# Altium schematic sheet Interface.SchDoc(Interface)
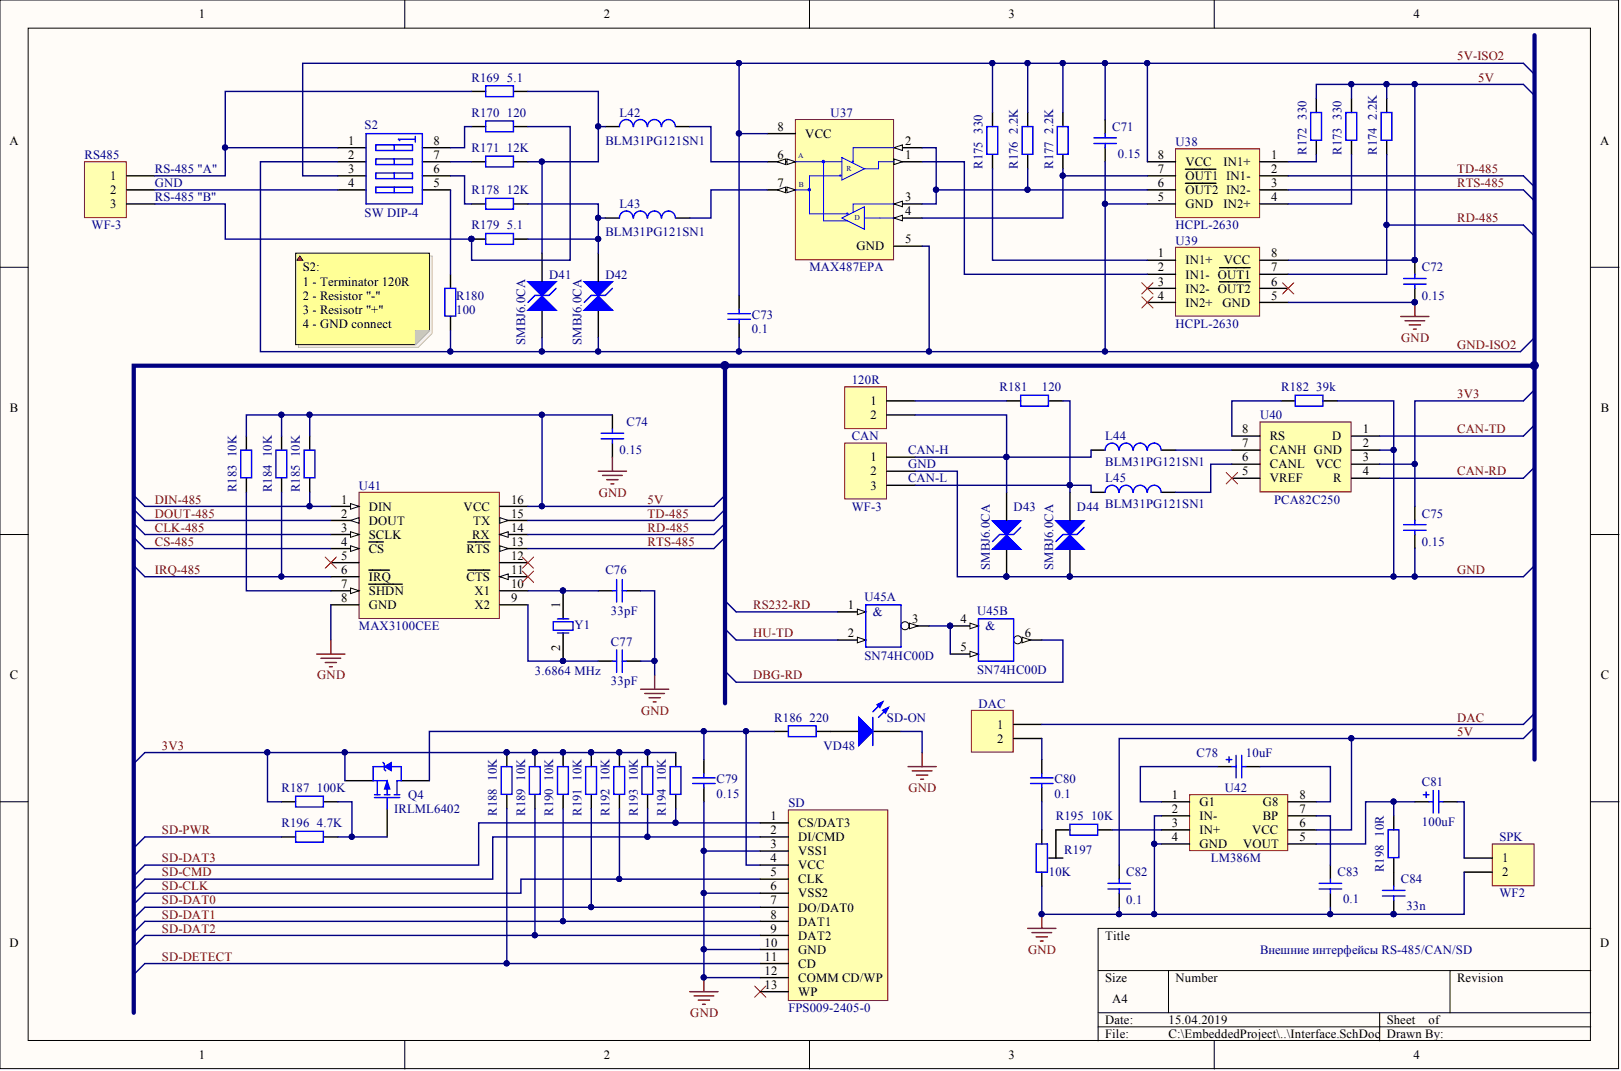
[diagram p0: Interface.SchDoc]

## Parts
120R  JP2  [HDR1X2]  (value not drawn)
C71  value 0.15  [C1206]  (hidden comment: Cap)
C72  value 0.15  [C1206]  (hidden comment: Cap)
C73  value 0.1  [C1206]  (hidden comment: Cap)
C74  value 0.15  [C1206]  (hidden comment: Cap)
C75  value 0.15  [C1206]  (hidden comment: Cap)
C76  value 33pF  [C1206]  (hidden comment: Cap)
C77  value 33pF  [C1206]  (hidden comment: Cap)
C78  value 10uF  [D]  (hidden comment: Polarized Capacitor)
C79  value 0.15  [C1206]  (hidden comment: Cap)
C80  value 0.1  [C1206]  (hidden comment: Cap)
C81  value 100uF  [D]  (hidden comment: Polarized Capacitor)
C82  value 0.1  [C1206]  (hidden comment: Cap)
C83  value 0.1  [C1206]  (hidden comment: Cap)
C84  value 33n  [C1206]  (hidden comment: Cap)
CAN  value WF-3  [WF-3]  (hidden comment: Header, 3-Pin)
D41  SMBJ6.0CA  [DO-214aa]
D42  SMBJ6.0CA  [DO-214aa]
D43  SMBJ6.0CA  [DO-214aa]
D44  SMBJ6.0CA  [DO-214aa]
L42  value BLM31PG121SN1  [L1206]  (hidden comment: Ferrite Chip Beads)
L43  value BLM31PG121SN1  [L1206]  (hidden comment: Ferrite Chip Beads)
L44  value BLM31PG121SN1  [L1206]  (hidden comment: Ferrite Chip Beads)
L45  value BLM31PG121SN1  [L1206]  (hidden comment: Ferrite Chip Beads)
Q4  IRLML6402  [SOT-23A_N]
R169  value 5.1  [1206]  (hidden comment: Res2)
R170  value 120  [1206]  (hidden comment: Res2)
R171  value 12K  [1206]  (hidden comment: Res2)
R172  value 330  [1206]  (hidden comment: Res2)
R173  value 330  [1206]  (hidden comment: Res2)
R174  value 2.2K  [1206]  (hidden comment: Res2)
R175  value 330  [1206]  (hidden comment: Res2)
R176  value 2.2K  [1206]  (hidden comment: Res2)
R177  value 2.2K  [1206]  (hidden comment: Res2)
R178  value 12K  [1206]  (hidden comment: Res2)
R179  value 5.1  [1206]  (hidden comment: Res2)
R180  value 100  [1206]  (hidden comment: Res2)
R181  value 120  [1206]  (hidden comment: Res2)
R182  value 39k  [1206]  (hidden comment: Res2)
R183  value 10K  [1206]  (hidden comment: Res2)
R184  value 10K  [1206]  (hidden comment: Res2)
R185  value 10K  [1206]  (hidden comment: Res2)
R186  value 220  [1206]  (hidden comment: Res2)
R187  value 100K  [1206]  (hidden comment: Res2)
R188  value 10K  [1206]  (hidden comment: Res2)
R189  value 10K  [1206]  (hidden comment: Res2)
R190  value 10K  [1206]  (hidden comment: Res2)
R191  value 10K  [1206]  (hidden comment: Res2)
R192  value 10K  [1206]  (hidden comment: Res2)
R193  value 10K  [1206]  (hidden comment: Res2)
R194  value 10K  [1206]  (hidden comment: Res2)
R195  value 10K  [1206]  (hidden comment: Res2)
R196  value 4.7K  [1206]  (hidden comment: Res2)
R197  value 10K  [R-Tr 3362P]  (hidden comment: Resistor TAP)
R198  value 10R  [1206]  (hidden comment: Res2)
RS485  value WF-3  [WF-3]  (hidden comment: Header 3)
S2  SW DIP-4  [SW DIP-8]
SD  FPS009-2405-0  [FPS009-2405-0]
SND  DAC  [HDR1X2]
SPK  WF2  [WF-2]
U37  value MAX487EPA  [DIP-8]  (hidden comment: Low-Power, Slew-Rate-Limited RS-485/RS-422 Transceiver)
U38  HCPL-2630  [DIP-8]
U39  HCPL-2630  [DIP-8]
U40  value PCA82C250  [8 pin SOIC_L]  (hidden comment: CAN transiver)
U41  MAX3100CEE  [QSOP-QR16_L]
U42  value LM386M  [8 pin SOIC_L]  (hidden comment: Audio Power Amplifier)
U45  SN74HC00D  [SOIC14_L]  (parts A,B)
VD48  SD-ON  [LED1206 - WHITE]
Y1  value 3.6864 MHz  [HC49S]  (hidden comment: Crystal Oscillator)

## Nets
VDD: U45.14
3V3: C75.1 Q4.2 R187.1 R188.2 R189.2 R190.2 R191.2 R192.2 R193.2 R194.2 U40.3(VCC)
5V: C72.1 C74.1 C82.2 R172.1 R173.1 R174.1 R183.1 R184.1 R185.1 U39.8(VCC) U41.16(VCC) U42.6(VCC)
5V-ISO2: C71.1 C73.1 R175.1 R176.1 R177.1 S2.3 U37.8(VCC) U38.8(VCC)
CAN-RD: U40.4(R)
CAN-TD: U40.1(D)
CLK-485: U41.3(SCLK)
CS-485: U41.4(CS)
DAC: SND.1
DBG-RD: U45.6
DIN-485: R185.2 U41.1(DIN)
DOUT-485: U41.2(DOUT)
GND: C72.2 C74.2 C75.2 C76.1 C77.1 C79.2 C82.1 C83.1 C84.1 CAN.2 D43.1 D44.1 R182.1 R197.1 SD.3(VSS1) SD.6(VSS2) SD.10(GND) SD.12(COMM_CD/WP) SPK.2 U39.5(GND) U40.2(GND) U41.8(GND) U42.2(IN-) U42.4(GND) U45.7 VD48.2
GND-ISO2: C71.2 C73.2 D41.1 D42.1 R180.1 S2.2 U37.5(GND) U38.5(GND)
HU-TD: U45.2
IRQ-485: R184.2 U41.6(IRQ)
RD-485: R174.2 U39.7(OUT1) U41.14(RX)
RS232-RD: U45.1(&)
RTS-485: U38.3(IN2-) U41.13(RTS)
SD-CLK: R192.1 SD.5(CLK)
SD-CMD: R193.1 SD.2(DI/CMD)
SD-DAT0: R191.1 SD.7(DO/DAT0)
SD-DAT1: R190.1 SD.8(DAT1)
SD-DAT2: R189.1 SD.9(DAT2)
SD-DAT3: R194.1 SD.1(CS/DAT3)
SD-DETECT: R188.1 SD.11(CD)
SD-PWR: R196.2
TD-485: U38.2(IN1-) U41.15(TX)
Net120R_1: 120R.1 R181.1
Net120R_2: 120R.2 CAN.1 D43.2 L44.1
NetC76_2: C76.2 U41.10(X1) Y1.1
NetC77_2: C77.2 U41.9(X2) Y1.2
NetC78_1: C78.1 U42.1(G1)
NetC78_2: C78.2 U42.8(G8)
NetC79_1: C79.1 Q4.3 R186.2 SD.4(VCC)
NetC80_1: C80.1 SND.2
NetC80_2: C80.2 R197.3
NetC81_1: C81.1 R198.2 U42.5(VOUT)
NetC81_2: C81.2 SPK.1
NetC83_2: C83.2 U42.7(BP)
NetC84_2: C84.2 R198.1
NetCAN_3: CAN.3 D44.2 L45.1 R181.2
NetD41_2: D41.2 L42.1 R169.1 R171.1
NetD42_2: D42.2 L43.1 R178.1 R179.1
NetL42_2: L42.2 U37.6
NetL43_2: L43.2 U37.7
NetL44_2: L44.2 U40.7(CANH)
NetL45_2: L45.2 U40.6(CANL)
NetQ4_1: Q4.1 R187.2 R196.1
NetR169_2: R169.2 RS485.1 S2.1
NetR170_1: R170.1 S2.8
NetR170_2: R170.2 R179.2 RS485.3
NetR171_2: R171.2 S2.7
NetR172_2: R172.2 U38.1(IN1+)
NetR173_2: R173.2 U38.4(IN2+)
NetR175_2: R175.2 U39.1(IN1+)
NetR176_2: R176.2 U37.2 U37.3 U38.6(OUT2)
NetR177_2: R177.2 U37.4 U38.7(OUT1)
NetR178_2: R178.2 S2.6
NetR180_2: R180.2 S2.5
NetR182_2: R182.2 U40.8(RS)
NetR183_2: R183.2 U41.7(SHDN)
NetR186_1: R186.1 VD48.1
NetR195_1: R195.1 R197.2
NetR195_2: R195.2 U42.3(IN+)
NetRS485_2: RS485.2 S2.4
NetU37_1: U37.1 U39.2(IN1-)
NetU45_3: U45.3 U45.4(&) U45.5
N00407:

Not connected: SD 13(WP); U39 3(IN2-) 4(IN2+) 6(OUT2); U40 5(VREF); U41 5 11(CTS) 12

## Library data of the parts
120R = Header 2: Header, 2-Pin; value: Jumper; Component Kind: Standard; LatestRevisionDate: 17-Jul-2002; LatestRevisionNote: Re-released for DXP Platform.; Library Name: Miscellaneous Connectors.IntLib; Signal Integrity: Connector; Publisher: Altium Limited
C71-C77 C79 C80 C82-C84 = Cap: Capacitor; Component Kind: Standard; LatestRevisionDate: 17-Jul-2002; LatestRevisionNote: Re-released for DXP Platform.; Library Name: Miscellaneous Devices.IntLib; PackageReference: RAD-0.3; Published: 8-Jun-2000; Signal Integrity: Cap; Simulation: CAP; Publisher: Altium Limited
C78 C81 = Cap Pol2: Component Kind: Standard; Description: Polarized Capacitor; LatestRevisionDate: 17-Jul-2002; LatestRevisionNote: Re-released for DXP Platform.; Library Name: Miscellaneous Devices.IntLib; PackageReference: POLAR0.8; Published: 8-Jun-2000; Signal Integrity: Cap Pol; Simulation: CAP; Publisher: Altium Limited
CAN = Header 3: Component Kind: Standard; Description: Header, 3-Pin; LatestRevisionDate: 17-Jul-2002; LatestRevisionNote: Re-released for DXP Platform.; Library Name: Miscellaneous Connectors.IntLib; Signal Integrity: Connector; Publisher: Altium Limited
D41-D44 = SMBJ6.0CA: Voltage suppressor; Component Kind: Standard; Library Name: Schlib1.SchLib; Value: SMBJ6.0CA
L42-L45 = Inductor: Code_IEC: 1005; Code_JEITA: 0402; Component Kind: Standard; Description: Ferrite Chip Beads; LatestRevisionDate: 29-May-2009; LatestRevisionNote: IPC-7351 Footprint Added.; Library Name: Miscellaneous Devices.IntLib; PackageDescription: Chip Inductor; PackageReference: 0402-A; Published: 8-Jun-2000; Signal Integrity: Inductor; Simulation: INDUCTOR; Publisher: Altium Limited
Q4 = IRLML6401: HEXFET Power MOSFET; Component Kind: Standard; ComponentLink1Description: Manufacturer Link; ComponentLink1URL: http://www.irf.com/; ComponentLink2Description: Datasheet; ComponentLink2URL: http://www.irf.com/product-info/datasheets/data/IRLML6401.pdf; DatasheetVersion: 2-Jul-2001; Library Name: IR Discrete MOSFET - Power.IntLib; PackageDescription: 3-Pin SOT-23 Package 0.95 mm Pitch, 2.3 mm Lead Span; PackageReference: Micro3; PackageVersion: Jul-2001; Published: 17-Sep-2003; Simulation: IRLML6401; Value: IRLML6402; Publisher: Altium Limited
R169-R196 R198 = Res2: Resistor; Component Kind: Standard; LatestRevisionDate: 17-Jul-2002; LatestRevisionNote: Re-released for DXP Platform.; Library Name: Miscellaneous Devices.IntLib; PackageReference: AXIAL-0.4; Published: 8-Jun-2000; Signal Integrity: Res; Simulation: RESISTOR; Publisher: Altium Limited
R197 = Res TAP: Code_IPC: RESC2012; Component Kind: Standard; Description: Resistor TAP; LatestRevisionDate: 29-May-2009; LatestRevisionNote: IPC-7351 Footprint Added.; Library Name: Schlib1.SchLib; PackageDescription: Chip Resistor 0805 Case Size; PackageReference: 6-0805; PackageVersion: Mar-2003; Published: 24-Mar-1999; Publisher: Altium Limited
RS485 = Header 3: Header, 3-Pin; Component Kind: Standard; LatestRevisionDate: 17-Jul-2002; LatestRevisionNote: Re-released for DXP Platform.; Library Name: Miscellaneous Connectors.IntLib; Signal Integrity: Connector; Publisher: Altium Limited
S2 = SW DIP-4: DIP Switch, 4 Position, SPST; Code_IPC: SOIC127P600-8; Code_JEDEC: MS-012-AA; Component Kind: Standard; LatestRevisionDate: 29-May-2009; LatestRevisionNote: IPC-7351 Footprint Added.; Library Name: Miscellaneous Devices.IntLib; PackageDescription: 8-Pin Small Outline Integrated Circuit 1.27 mm Pitch; PackageReference: SO8; PackageVersion: Dec-2002; Published: 24-Mar-1999; Simulation: dpsw4; Publisher: Altium Limited
SD = FPS009-2405-0: MMC-SD Slot; Component Kind: Standard; Library Name: Schlib1.SchLib; Value: FPS009-2405-0
SND = Header 2: Header, 2-Pin; VALUE: JUMPER; Component Kind: Standard; LatestRevisionDate: 17-Jul-2002; LatestRevisionNote: Re-released for DXP Platform.; Library Name: Miscellaneous Connectors.IntLib; Signal Integrity: Connector; Publisher: Altium Limited
SPK = Header 2: Header, 2-Pin; VALUE: WF-2; Component Kind: Standard; LatestRevisionDate: 17-Jul-2002; LatestRevisionNote: Re-released for DXP Platform.; Library Name: Miscellaneous Connectors.IntLib; Signal Integrity: Connector; Publisher: Altium Limited
U37 = MAX487CPA: Code_JEDEC: MS-001-AB; Component Kind: Standard; ComponentLink1Description: Manufacturer Link; ComponentLink1URL: http://www.maxim-ic.com/; ComponentLink2Description: Datasheet; ComponentLink2URL: http://pdfserv.maxim-ic.com/en/ds/MAX1487-MAX491.pdf; DatasheetVersion: Feb-1996; Description: Low-Power, Slew-Rate-Limited RS-485/RS-422 Transceiver; LatestRevisionDate: 29-May-2009; LatestRevisionNote: Component Body Added.; Library Name: Maxim Communication Transceiver.IntLib; PackageDescription: DIP, 8-Pin, Row Spacing 7.62 mm, Pitch 2.54 mm; PackageReference: PA8; PackageVersion: RevB, 21-0043; PCB3D: PA8; Published: 16-Jan-2001; Publisher: Altium Limited
U38 U39 = HCPL-2630: High speed-10 mbit/s logic gate optocouplers dual-channel; Component Kind: Standard; Library Name: Schlib1.SchLib; Value: HCPL-2630
U40 = SN65HVD230: Component Kind: Standard; Description: CAN transiver; Library Name: Schlib1.SchLib
U41 = MAX3100CEE: SPI/MICROWIRE-Compatible  UART in QSOP-16; Component Kind: Standard; Library Name: Schlib1.SchLib; Value: MAX3100CEE
U42 = LM386M: Component Kind: Standard; Description: Audio Power Amplifier; Library Name: Schlib1.SchLib
U45 = SN74HC00D: Quad 2-Input NAND Buffered B series Gate, 14-Pin; IC_Technology: C; Component Kind: Standard; ComponentLink1Description: Manufacturer Link; ComponentLink1URL: http://www.fairchildsemi.com/; ComponentLink2Description: Datasheet; ComponentLink2URL: http://www.fairchildsemi.com/ds/CD%2FCD4001BC.pdf; DatasheetVersion: Jan-1999; LatestRevisionDate: 29-May-2009; LatestRevisionNote: Component Body Added.; Library Name: Schlib1.SchLib; PackageDescription: DIP, 14-Pin, Row Spacing 7.62 mm, Pitch 2.54 mm; PackageReference: N14A; PackageVersion: 2000; Published: 17-Jul-2002; Simulation: FSC_4011; Value: SN74HC00D; Publisher: Altium Limited
VD48 = LED0: SMD LED; value: WHITE; Component Kind: Standard; LatestRevisionDate: 08-Jul-2005; LatestRevisionNote: Mech Layer 1 removed.; Library Name: Miscellaneous Devices.IntLib; PackageReference: LED-0; Published: 8-Jun-2000; Simulation: LED0; Publisher: Altium Limited
Y1 = XTAL: Component Kind: Standard; Description: Crystal Oscillator; LatestRevisionDate: 17-Jul-2002; LatestRevisionNote: Re-released for DXP Platform.; Library Name: Miscellaneous Devices.IntLib; PackageDescription: Crystal, Thru-Hole; 2 Leads; Body 3.1 x 8.2 mm (Dia.xH); PackageReference: R38; Published: 8-Jun-2000; Simulation: XTAL; Publisher: Altium Limited
